# Altium schematic sheet Stage3C_digital_pot.SchDoc
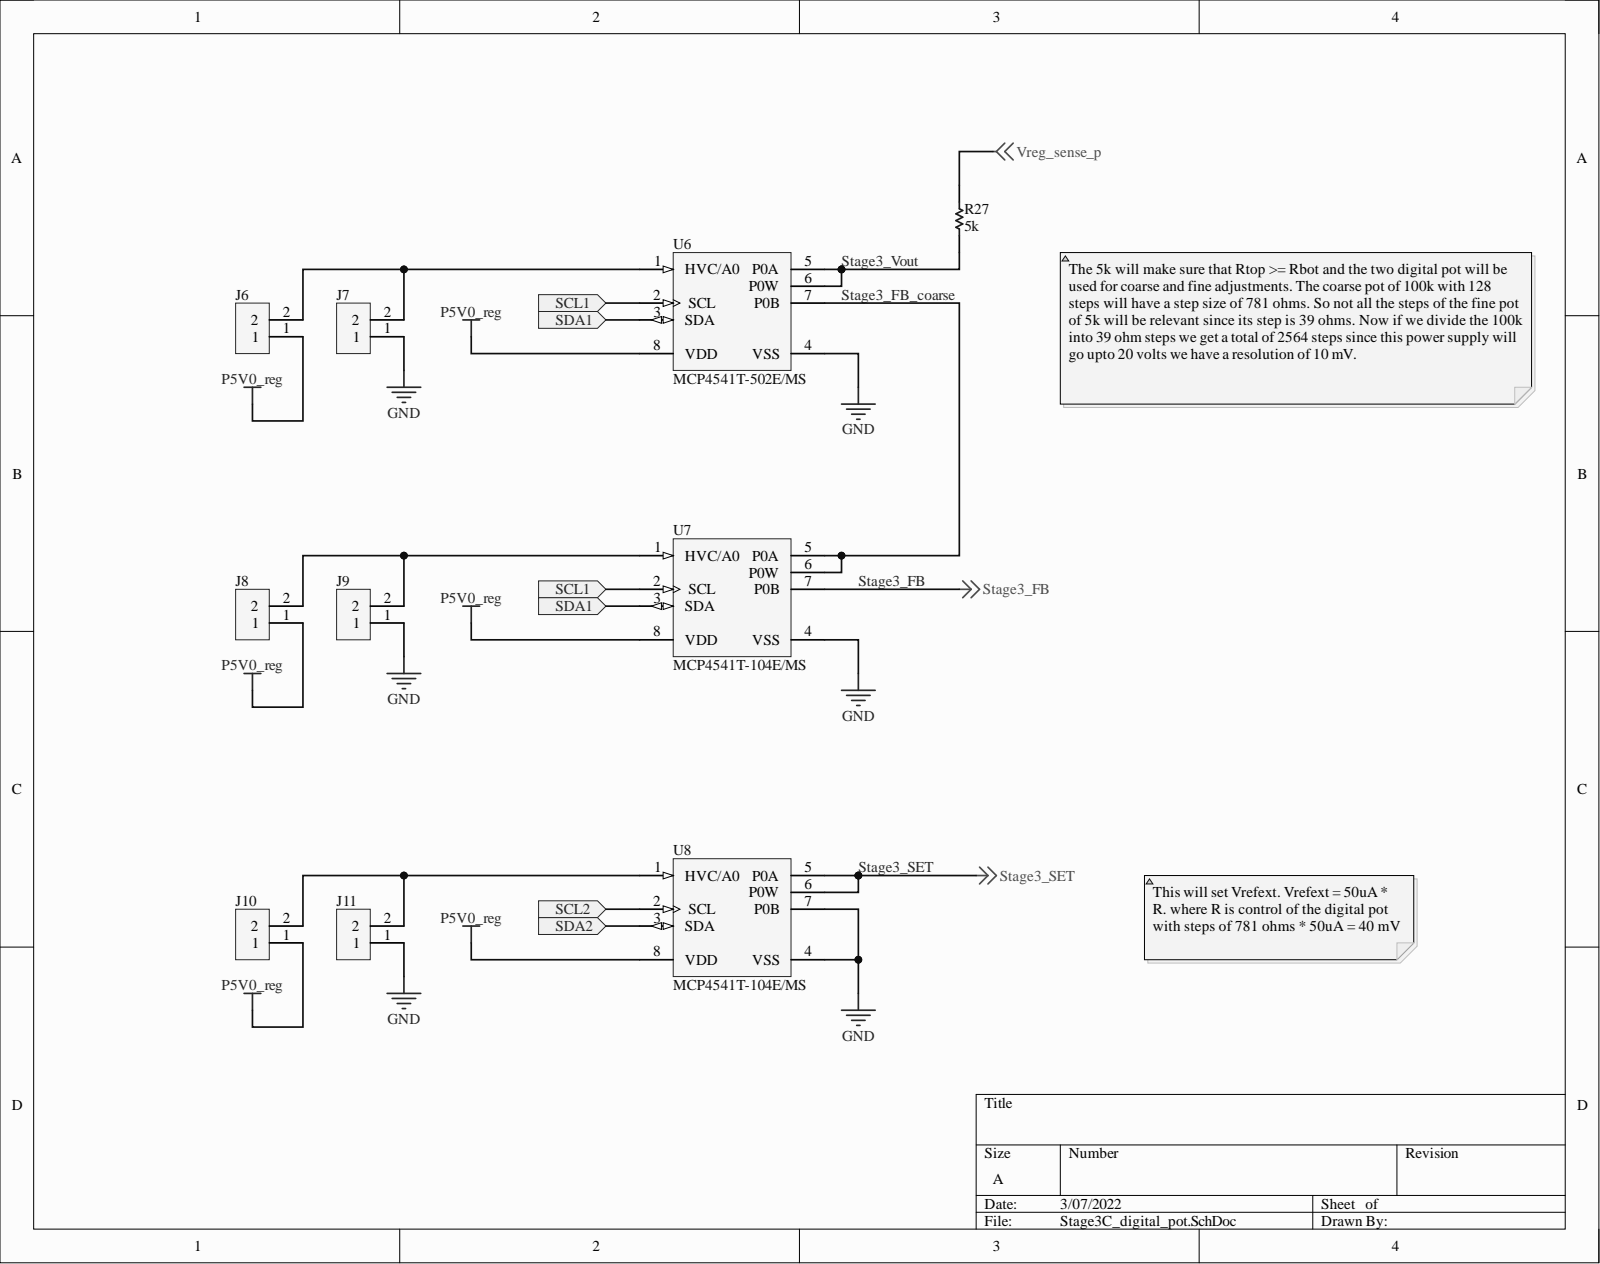
[diagram p0: Stage3C_digital_pot.SchDoc]

## Parts
J6  (no value)  [PCB-omgsbkhp90n7lpri88og-1]
J7  (no value)  [PCB-omgsbkhp90n7lpri88og-1]
J8  (no value)  [PCB-omgsbkhp90n7lpri88og-1]
J9  (no value)  [PCB-omgsbkhp90n7lpri88og-1]
J10  (no value)  [PCB-omgsbkhp90n7lpri88og-1]
J11  (no value)  [PCB-omgsbkhp90n7lpri88og-1]
R27  5k  [PCB-uip3f7nxj24hdkeeu2m5-1]
U6  MCP4541T-502E/MS  [MSOP-MS8_N]
U7  MCP4541T-104E/MS  [MSOP-MS8_L]
U8  MCP4541T-104E/MS  [MSOP-MS8_L]

## Ports
SCL1, SCL2, SDA1, SDA2

## Nets
GND: J7.1 J9.1 J11.1 U6.4(VSS) U7.4(VSS) U8.4(VSS) U8.7(P0B)
P5V0_reg: J6.1 J8.1 J10.1 U6.8(VDD) U7.8(VDD) U8.8(VDD)
Vreg_sense_p: R27.2
SCL1: U6.2(SCL) U7.2(SCL) ; port SCL1
SCL2: U8.2(SCL) ; port SCL2
SDA1: U6.3(SDA) U7.3(SDA) ; port SDA1
SDA2: U8.3(SDA) ; port SDA2
Stage3_FB: U7.7(P0B)
Stage3_FB_coarse: U6.7(P0B) U7.5(P0A) U7.6(P0W)
Stage3_SET: U8.5(P0A) U8.6(P0W)
Stage3_Vout: R27.1 U6.5(P0A) U6.6(P0W)
NetJ6_2: J6.2 J7.2 U6.1(HVC/A0)
NetJ8_2: J8.2 J9.2 U7.1(HVC/A0)
NetJ10_2: J10.2 J11.2 U8.1(HVC/A0)

## Library data of the parts
J6-J11 = CMP-748fbcb73d6bab48-30: Pitch 2.54 mm, Single Row, 2pins; Component Kind: Standard; Library Name: My Personal Space
R27 = CMP-68e4a35bf371b723-1: Res Thick Film 0805 0.82 Ohm 5% 0.25W(1/4W) ±200ppm/C Epoxy SMD Automotive T/R; Manufacturer Part Number: RLP73K2AR82JTD; Case/Package (SI): 2012; ELV Compliant: Compliant; Power Rating: 250 mW; Resistance: 820 mΩ; Case/Package: 0805, 2012; Component Kind: Standard; Library Name: My Personal Space; Lifecycle Status: Not Listed by Manufacturer; Mounting Style: Surface Mount; Octopart URL: http://octopart.com/rlp73k2ar82jtd-te+connectivity-45465331; OctopartID: 68e4a35bf371b723; Packaging: Tape & Reel (TR); REACH SVHC Compliance: No SVHC; Resistance Tolerance: ±5%; RoHS: Compliant
U6 = CMP-1074023-3: 7-Bit Single I2C Digital POT with Non-Volatile Memory, 8-pin MSOP, Extended Temperature, Tape and Reel; Resistance Tolerance: ±20%; Component Kind: Standard; Library Name: My Personal Space; Lifecycle Status: Active; Manufacturer Part Number: MCP4541T-502E/MS; Octopart Url: https://octopart.com/mcp4541t-502e%2Fms-microchip-16787150; OctopartID: 3faff7a53c07e068; RoHS: Compliant
U7 U8 = CMP-1074022-3: 7-Bit Single I2C Digital POT with Non-Volatile Memory, 8-pin MSOP, Extended Temperature, Tape and Reel; Resistance Tolerance: ±20%; Component Kind: Standard; Library Name: My Personal Space; Lifecycle Status: Active; Manufacturer Part Number: MCP4541T-104E/MS; Octopart Url: https://octopart.com/mcp4541t-104e%2Fms-microchip-16096136; OctopartID: a1f1680e1f1f7e36; RoHS: Compliant
